# Altium schematic sheet ZX1541 Interfac in THT.SchDoc("ZX1541 Interfac in THT")
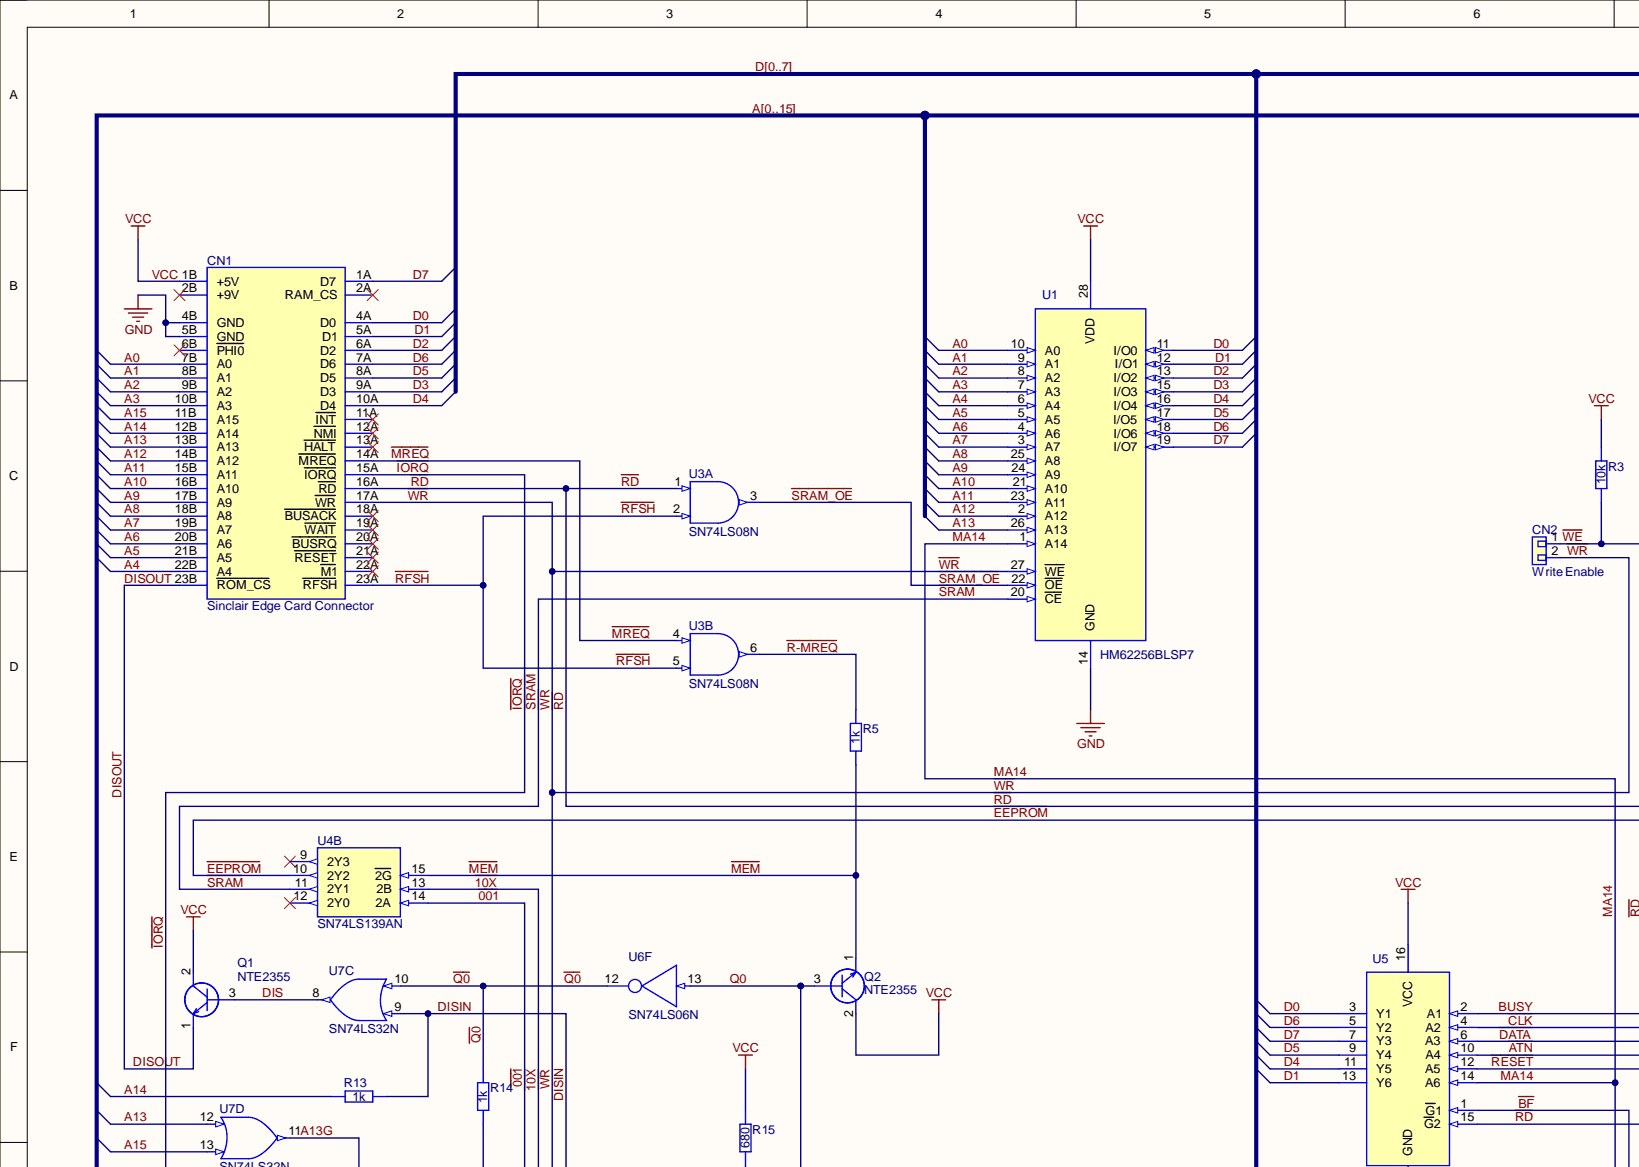
[diagram p0: ZX1541 Interfac in THT.SchDoc, top left]
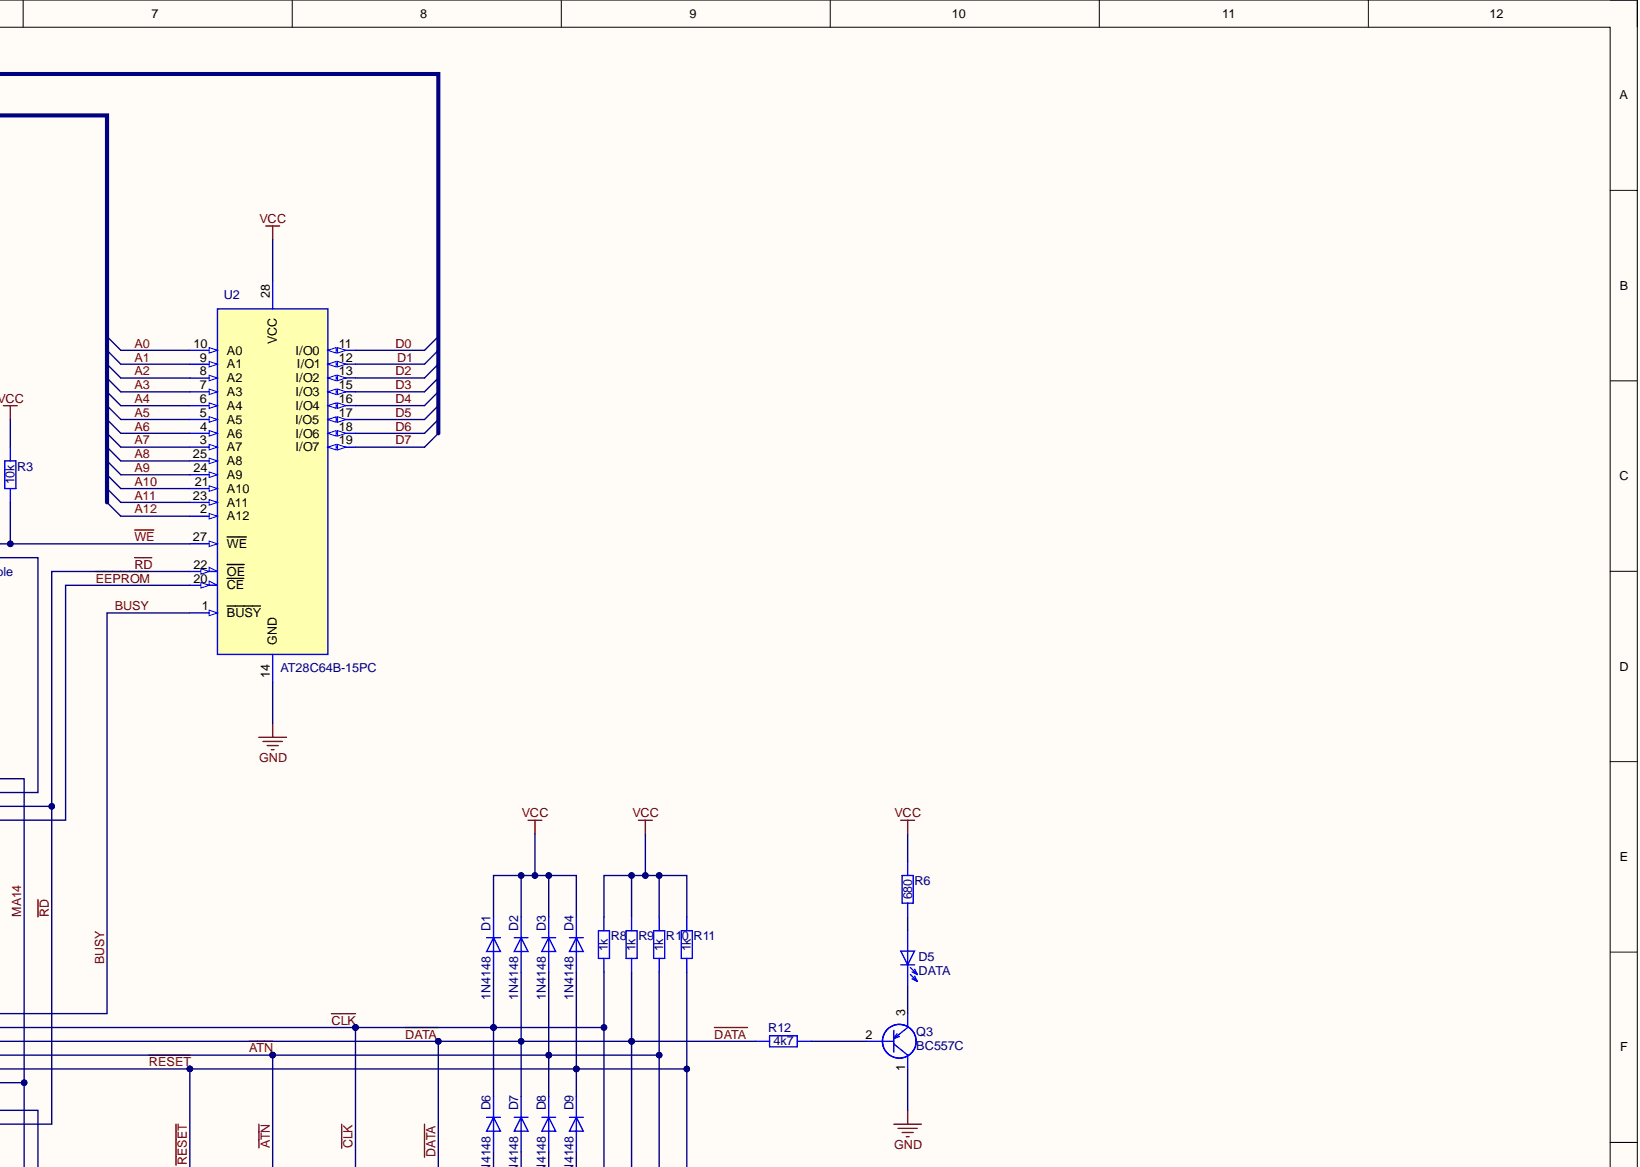
[diagram p1: ZX1541 Interfac in THT.SchDoc, top right]
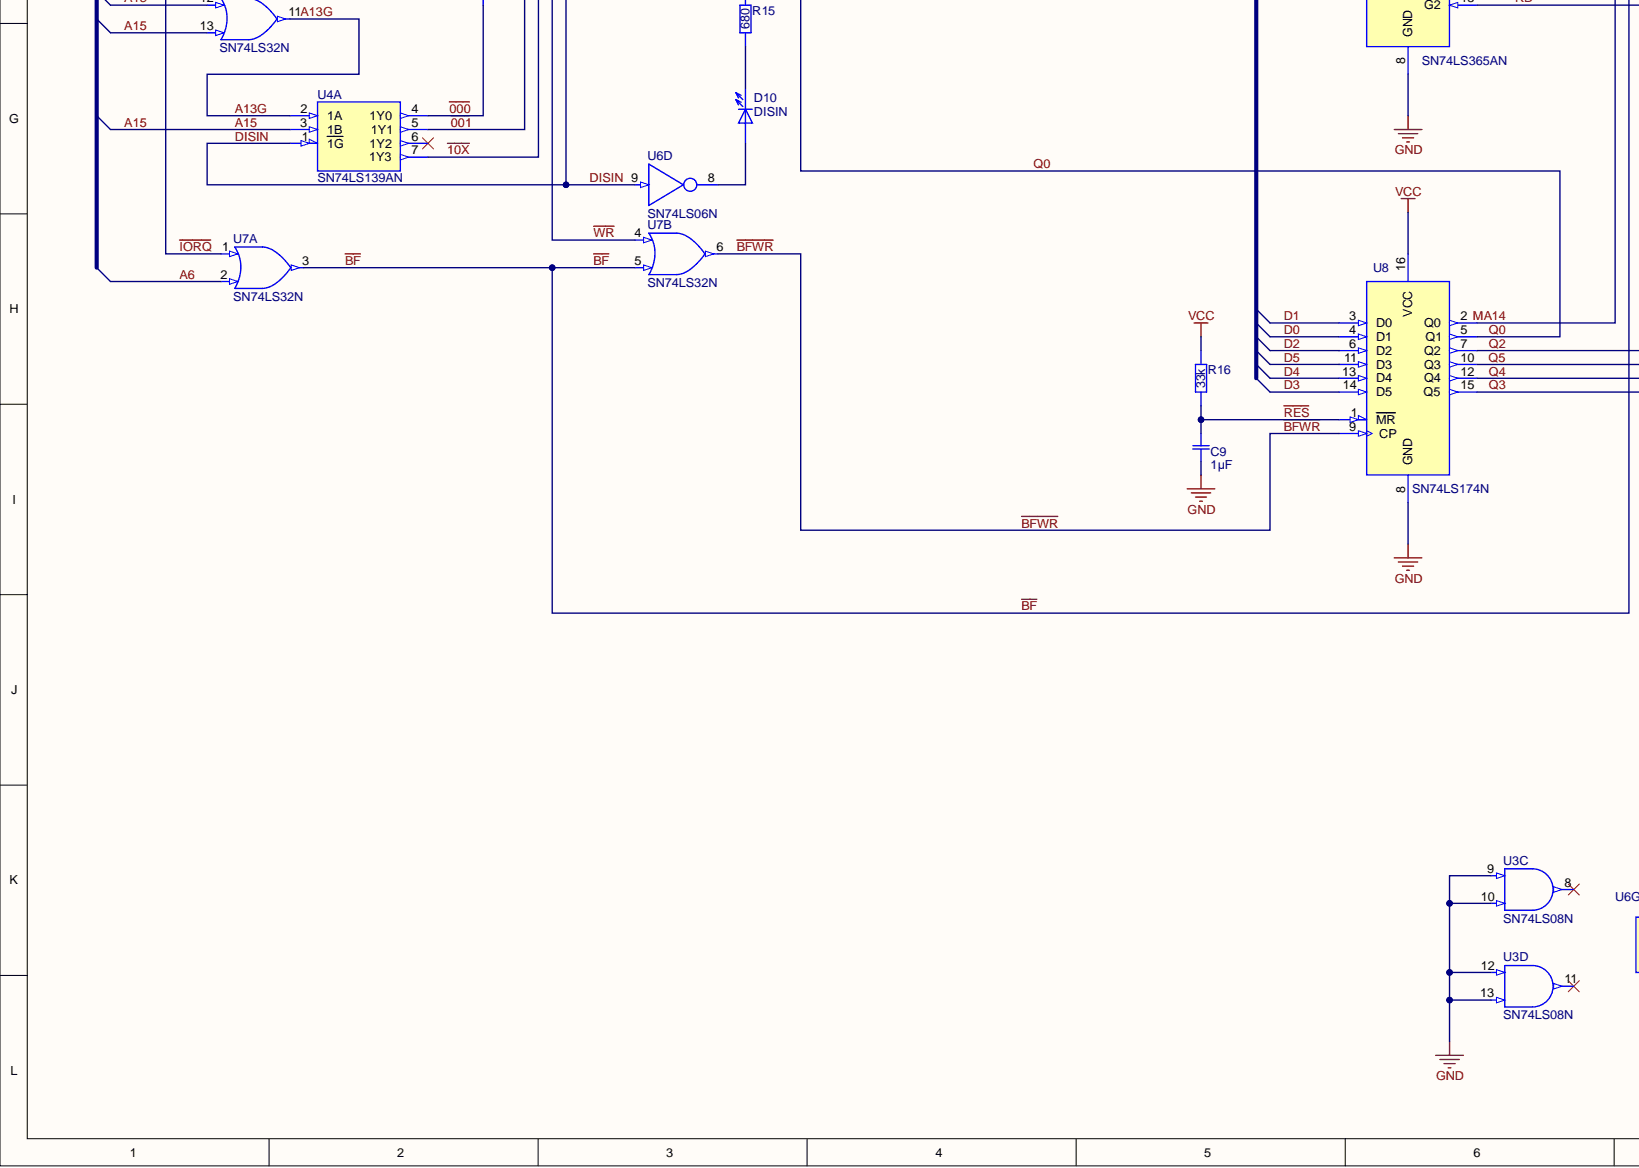
[diagram p2: ZX1541 Interfac in THT.SchDoc, bottom left]
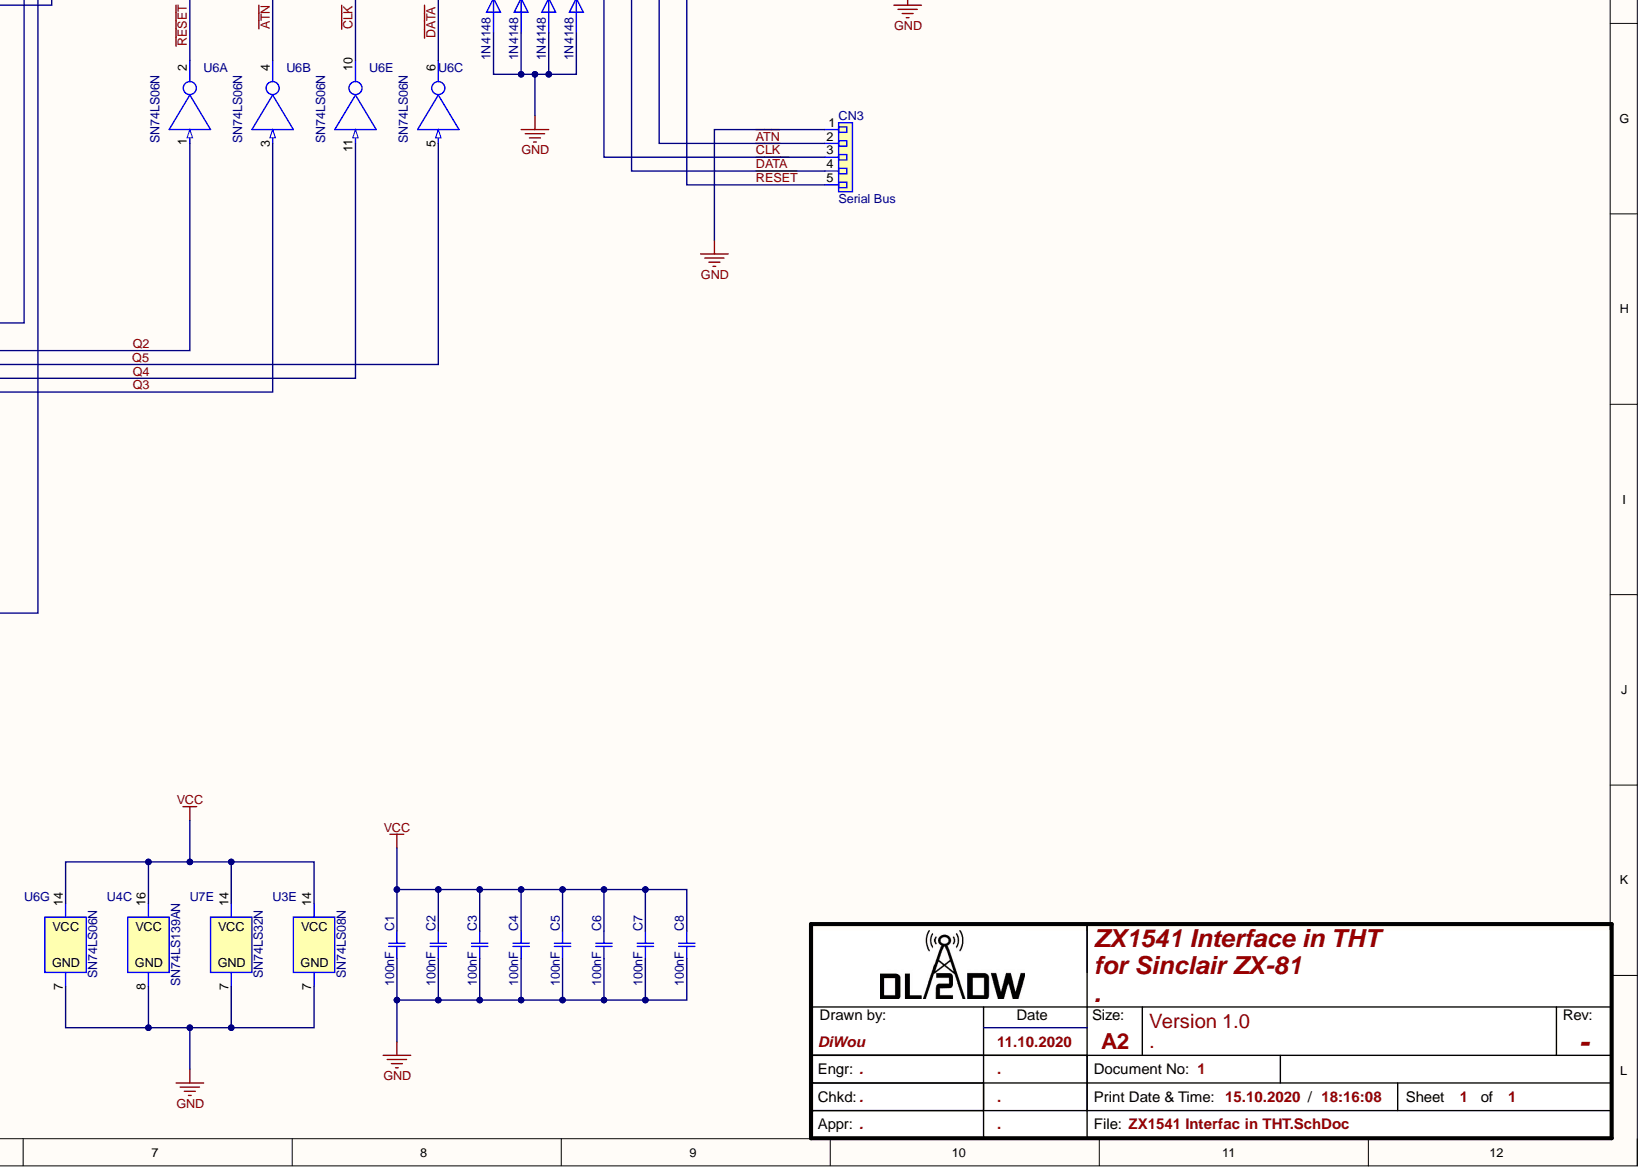
[diagram p3: ZX1541 Interfac in THT.SchDoc, bottom right]

## Parts
C1  100nF  [CAP Disc 100nF P2.54mm D5.5mm T3mm]
C2  100nF  [CAP Disc 100nF P2.54mm D5.5mm T3mm]
C3  100nF  [CAP Disc 100nF P2.54mm D5.5mm T3mm]
C4  100nF  [CAP Disc 100nF P2.54mm D5.5mm T3mm]
C5  100nF  [CAP Disc 100nF P2.54mm D5.5mm T3mm]
C6  100nF  [CAP Disc 100nF P2.54mm D5.5mm T3mm]
C7  100nF  [CAP Disc 100nF P2.54mm D5.5mm T3mm]
C8  100nF  [CAP Disc 100nF P2.54mm D5.5mm T3mm]
C9  1µF  [CAP 1µF C315C105K3R5TA P2.54mm]
CN1  Sinclair Edge Card Connector  [Sinclair Card Connector]
CN2  Write Enable  [Pin Header 01x02 2.54mm Horizontal Male]
CN3  Serial Bus  [01x05 RM2.0 mm Male Pin Header - MPE-Garry 150-1-005-0-S-XS0-0835]
D1  1N4148  [1N4148 P=5.08mm]
D2  1N4148  [1N4148 P=5.08mm]
D3  1N4148  [1N4148 P=5.08mm]
D4  1N4148  [1N4148 P=5.08mm]
D5  DATA  [LED 3mm Red Right Angle 4mm]
D6  1N4148  [1N4148 P=5.08mm]
D7  1N4148  [1N4148 P=5.08mm]
D8  1N4148  [1N4148 P=5.08mm]
D9  1N4148  [1N4148 P=5.08mm]
D10  DISIN  [LED 3mm Green Right Angle 4mm]
Q1  NTE2355  [NTE 2355]
Q2  NTE2355  [NTE 2355]
Q3  BC557C  [BC557C]
R3  10k  [10 kOhm R Axial DIN0207 L6.3mm D2.5mm P7.62mm Horizontal]
R5  1k  [1,0 kOhm R Axial DIN0207 L6.3mm D2.5mm P7.62mm Horizontal]
R6  680  [680 kOhm R Axial DIN0207 L6.3mm D2.5mm P7.62mm Horizontal]
R8  1k  [1,0 kOhm R Axial DIN0207 L6.3mm D2.5mm P7.62mm Horizontal]
R9  1k  [1,0 kOhm R Axial DIN0207 L6.3mm D2.5mm P7.62mm Horizontal]
R10  1k  [1,0 kOhm R Axial DIN0207 L6.3mm D2.5mm P7.62mm Horizontal]
R11  1k  [1,0 kOhm R Axial DIN0207 L6.3mm D2.5mm P7.62mm Horizontal]
R12  4k7  [4,7 kOhm R Axial DIN0207 L6.3mm D2.5mm P7.62mm Horizontal]
R13  1k  [1,0 kOhm R Axial DIN0207 L6.3mm D2.5mm P7.62mm Horizontal]
R14  1k  [1,0 kOhm R Axial DIN0207 L6.3mm D2.5mm P7.62mm Horizontal]
R15  680  [680 kOhm R Axial DIN0207 L6.3mm D2.5mm P7.62mm Horizontal]
R16  33k  [33 kOhm R Axial DIN0207 L6.3mm D2.5mm P7.62mm Horizontal]
U1  HM62256BLSP7  [Hitachi HM62256BLSP-7 SRAM 32kx8]
U2  AT28C64B-15PC  Memory Type: EEPROM  [28C64-150 with precision Socket]
U3  SN74LS08N  [SN74LS08N with Socket]  (parts A,B,C,D,E)
U4  SN74LS139AN  [SN74LS139N with Socket]  (parts A,B,C)
U5  SN74LS365AN  [SN74LS365A with Socket]
U6  SN74LS06N  [SN74LS06N with Socket]  (parts A,B,C,D,E,F,G)
U7  SN74LS32N  [SN74LS32N with Socket]  (parts A,B,C,D,E)
U8  SN74LS174N  [SN74LS174N with Socket]

## Nets
GND: C1.1 C2.1 C3.1 C4.1 C5.1 C6.1 C7.1 C8.1 C9.1 CN1.4B(GND) CN1.5B(GND) CN3.1 D6.2 D7.2 D8.2 D9.2 Q3.1 U1.14(GND) U2.14(GND) U3.7 U3.9 U3.10 U3.12 U3.13 U4.8 U5.8(GND) U6.7 U7.7 U8.8(GND)
~{000}: R14.1 U4.4(1Y0)
~{001}: U4.5(1Y1) U4.14(2A)
~{10X}: U4.7(1Y3) U4.13(2B)
A0: CN1.7B(A0) U1.10(A0) U2.10(A0)  [also labelled A]
A1: CN1.8B(A1) U1.9(A1) U2.9(A1)  [also labelled A]
A2: CN1.9B(A2) U1.8(A2) U2.8(A2)  [also labelled A]
A3: CN1.10B(A3) U1.7(A3) U2.7(A3)  [also labelled A]
A4: CN1.22B(A4) U1.6(A4) U2.6(A4)  [also labelled A]
A5: CN1.21B(A5) U1.5(A5) U2.5(A5)  [also labelled A]
A6: CN1.20B(A6) U1.4(A6) U2.4(A6) U7.2  [also labelled A]
A7: CN1.19B(A7) U1.3(A7) U2.3(A7)  [also labelled A]
A8: CN1.18B(A8) U1.25(A8) U2.25(A8)  [also labelled A]
A9: CN1.17B(A9) U1.24(A9) U2.24(A9)  [also labelled A]
A10: CN1.16B(A10) U1.21(A10) U2.21(A10)  [also labelled A]
A11: CN1.15B(A11) U1.23(A11) U2.23(A11)  [also labelled A]
A12: CN1.14B(A12) U1.2(A12) U2.2(A12)  [also labelled A]
A13: CN1.13B(A13) U1.26(A13) U7.12  [also labelled A]
A13G: U4.2(1A) U7.11
A14: CN1.12B(A14) R13.1  [also labelled A]
A15: CN1.11B(A15) U4.3(1B) U7.13  [also labelled A]
~{ATN}: CN3.2 D3.2 D8.1 R10.1 U5.10(A4) U6.4
~{BF}: U5.1(G1) U7.3 U7.5
~{BFWR}: U7.6 U8.9(CP)
BUSY: U2.1(BUSY) U5.2(A1)
~{CLK}: CN3.3 D1.2 D6.1 R8.1 U5.4(A2) U6.10
D0: CN1.4A(D0) U1.11(I/O0) U2.11(I/O0) U5.3(Y1) U8.4(D1)  [also labelled D]
D1: CN1.5A(D1) U1.12(I/O1) U2.12(I/O1) U5.13(Y6) U8.3(D0)  [also labelled D]
D2: CN1.6A(D2) U1.13(I/O2) U2.13(I/O2) U8.6(D2)  [also labelled D]
D3: CN1.9A(D3) U1.15(I/O3) U2.15(I/O3) U8.14(D5)  [also labelled D]
D4: CN1.10A(D4) U1.16(I/O4) U2.16(I/O4) U5.11(Y5) U8.13(D4)  [also labelled D]
D5: CN1.8A(D5) U1.17(I/O5) U2.17(I/O5) U5.9(Y4) U8.11(D3)  [also labelled D]
D6: CN1.7A(D6) U1.18(I/O6) U2.18(I/O6) U5.5(Y2)  [also labelled D]
D7: CN1.1A(D7) U1.19(I/O7) U2.19(I/O7) U5.7(Y3)  [also labelled D]
~{DATA}: CN3.4 D2.2 D7.1 R9.1 R12.1 U5.6(A3) U6.6
DIS: Q1.3 U7.8
DISIN: R13.2 U4.1(1G) U6.9 U7.9
DISOUT: CN1.23B(ROM_CS) Q1.1
~{EEPROM}: U2.20(CE) U4.10(2Y2)
~{IORQ}: CN1.15A(IORQ) U7.1
~{MEM}: Q2.1 R5.1 U4.15(2G)
~{MREQ}: CN1.14A(MREQ) U3.4
MA14: U1.1(A14) U5.14(A6) U8.2(Q0)
Q0: Q2.3 U6.13 U8.5(Q1)
Q2: U6.1 U8.7(Q2)
Q3: U6.3 U8.15(Q5)
Q4: U6.11 U8.12(Q4)
Q5: U6.5 U8.10(Q3)
~{Q0}: R14.2 U6.12 U7.10
~{R-MREQ}: R5.2 U3.6
~{RD}: CN1.16A(RD) U2.22(OE) U3.1 U5.15(G2)
~{RES}: C9.2 R16.1 U8.1(MR)
~{RESET}: CN3.5 D4.2 D9.1 R11.1 U5.12(A5) U6.2
~{RFSH}: CN1.23A(RFSH) U3.2 U3.5
~{SRAM}: U1.20(CE) U4.11(2Y1)
~{SRAM_OE}: U1.22(OE) U3.3
VCC: C1.2 C2.2 C3.2 C4.2 C5.2 C6.2 C7.2 C8.2 CN1.1B(+5V) D1.1 D2.1 D3.1 D4.1 Q1.2 Q2.2 R3.2 R6.2 R8.2 R9.2 R10.2 R11.2 R15.2 R16.2 U1.28(VDD) U2.28(VCC) U3.14 U4.16 U5.16(VCC) U6.14 U7.14 U8.16(VCC)
~{WE}: CN2.1 R3.1 U2.27(WE)
~{WR}: CN1.17A(WR) CN2.2 U1.27(WE) U7.4
NetD5_1: D5.1 Q3.3
NetD5_2: D5.2 R6.1
NetD10_1: D10.1 R15.1
NetD10_2: D10.2 U6.8
NetQ3_2: Q3.2 R12.2

Not connected: CN1 2A(RAM_CS) 2B(+9V) 6B(PHI0) 11A(INT) 12A(NMI) 13A(HALT) 18A(BUSACK) 19A(WAIT) 20A(BUSRQ) 21A(RESET) 22A(M1); U3 8 11; U4 6(1Y2) 9(2Y3) 12(2Y0)

## Library data of the parts
C1-C8 = CMP-Capacitors-Ceramic_Capacitors-00007-4: Ceramic Disc Capacitors 100nF -20%~+80% 50V Through Hole,P=2.54mm RoHS; Component Kind: Standard; ComponentLink1URL: https://lcsc.com/product-detail/Others_Dersonic-CC1H104ZA1PD3F5O3000_C353909.html; Library Name: PCB-Tech Cloud
C9 = CMP-Capacitors-Ceramic_Capacitors-00009-2: Multilayer Ceramic Capacitors MLCC - Leaded 25volts 1uF 10% X7R; Dissipation Factor: 3.5%; Lead Diameter: 0.51mm; Lead/Base Style: Straight; Temperature Coefficient: 2.2ppm/°C; Voltage: 25V; Capacitance: 1uF; Case/Package: Radial; Component Kind: Standard; Depth: 2.54mm; Dielectric: X7R; Dielectric Material: Ceramic; Height: 2.54mm; Height - Seated (Max): 0.21inch; Lead Pitch: 0.1inch; Length: 0.15inch; Library Name: PCB-Tech Cloud; Max Operating Temperature: 125°C; Min Operating Temperature: -55°C; Mount: Through Hole,PCB; Package Quantity: 500; Packaging: Bulk; Termination: Radial; Tolerance: 10%; Voltage Rating: 25V; Voltage Rating (DC): 25V; Width: 0.1inch
CN1 = CMP-Connectors-00027-6: Component Kind: Standard; Description: Sinclair Edge Card Connector; Library Name: PCB-Tech Cloud
CN2 = CMP-Connectors-Male_PIN_Headers-00001-4: CONN HEADER .100" SNGL STR 2POS; Connector Type: Male Pin; Number of Positions: 2; Pitch: 0.1inch; Color: Black; Component Kind: Standard; Contact Mating Length: 0.318inch; Contact Plating: Gold; Library Name: PCB-Tech Cloud; Mount: Through Hole; Number of Rows: 1; Package Quantity: 1; Packaging: Bulk; Termination: Solder
CN3 = CMP-Male_Pin_Headers-RM2.0_Male_Pin_Headers-00003-2: Conn Unshrouded Header HDR 5 POS 2mm Solder ST Thru-Hole Automotive Bulk; Gender: Male; Max Voltage Rating (AC): 500V; Number of Contacts: 5; Component Kind: Standard; Contact Material: Bronze; Contact Plating: Gold; Depth: 1.97mm; Housing Color: Black; Housing Material: Polymer; Lead Free: Lead Free; Length: 10mm; Library Name: PCB-Tech Cloud; Max Operating Temperature: 125°C; Min Operating Temperature: -55°C; Number of Rows: 1; Orientation: Straight; Packaging: Bulk; Pitch: 2mm; Radiation Hardening: No; Termination: Solder
D1-D4 D6-D9 = CMP-Diodes-00002-4: NEXPERIA - 1N4148 - DIODE, 1N4148 AMMO-BOX 10K; Capacitance: 4pF; Current: 4A; Current Rating: 200mA; Element Configuration: Single; Forward Voltage: 1V; Max Power Dissipation: 500mW; Max Repetitive Reverse Voltage (Vrrm): 100V; Polarity: Standard; Reverse Recovery Time: 4ns; Case/Package: SOD; Component Kind: Standard; Forward Current: 200mA; Height: 0.25inch; Lead Free: Lead Free; Length: 2.5inch; Library Name: PCB-Tech Cloud; Max Forward Surge Current (Ifsm): 4A; Max Operating Temperature: 200°C; Min Operating Temperature: -65°C; Number of Pins: 2; Output Current: 200mA; Packaging: Ammo Pack; Peak Non-Repetitive Surge Current: 4A; Radiation Hardening: No; REACH SVHC: No SVHC; Termination: Axial; Voltage: 100V; Voltage Rating (DC): 100V; Weight: 0.01lb; Width: 0.25inch
D5 = CMP-LEDs-00000-4: WURTH ELEKTRONIK   151033RS03000   LED, 3MM,  RED, 2600MCD, 621NM; Forward Current: 30mA; Forward Voltage: 2V; Lens Style: Clear,Circular,Round; Test Current: 20mA; Viewing Angle: 30°; Case/Package: Radial; Color: Red; Component Kind: Standard; Dominant Wavelength: 621nm; Height: 5.55mm; Lens Size: 3mm; Library Name: PCB-Tech Cloud; Luminous Intensity: 2600mcd; Max Operating Temperature: 85°C; Min Operating Temperature: -40°C; Mount: Through Hole; Package Quantity: 1; Packaging: Bulk; REACH SVHC: Unknown; Wavelength: 621nm
D10 = CMP-LEDs-00007-1: WURTH ELEKTRONIK - 151033GS03000 - LED, Green, T-1 (3mm), 530 nm, 3.2 V, 30 mA, 15 cd RoHS Compliant: Yes; Dominant Wavelength: 530nm; Forward Current: 30mA; Forward Voltage: 3.2V; Lens Style: Clear,Round,Circular; Luminous Intensity: 15000mcd; Test Current: 20mA; Viewing Angle: 30°; Case/Package: Radial; Color: Green; Component Kind: Standard; Height: 5.55mm; Lens Size: 3mm; Library Name: PCB-Tech Cloud; Max Operating Temperature: 85°C; Min Operating Temperature: -40°C; Mount: Through Hole; Package Quantity: 1; Packaging: Bulk; REACH SVHC: Unknown; Wavelength: 530nm
Q1 Q2 = CMP-Transistors-00009-1: PRE-BIASED DIG TRANSISTOR, 50V, TO-92; Transistor Polarity:NPN; Collector Emitter Voltage V(br)ceo:50V; Transition Frequency ft:250MHz; Power Dissipation Pd:300mW; DC Collector Current:100mA; DC Current Gain hFE:50; No. of Pins:3 ;RoHS Compliant: Yes; Element Configuration: Single; Max Collector Current: 200mA; Max Power Dissipation: 300mW; Polarity: NPN; Transition Frequency: 250MHz; Case/Package: TO-92; Collector Base Voltage (VCBO): 50V; Collector Emitter Breakdown Voltage: 50V; Collector Emitter Voltage (VCEO): 50V; Component Kind: Standard; Height: 0.5inch; Length: 6inch; Library Name: PCB-Tech Cloud; Max Operating Temperature: 150°C; Min Operating Temperature: -55°C; Mount: Through Hole; Number of Pins: 3; Power Dissipation: 300mW; REACH SVHC: No SVHC; Weight: 0.016lb; Width: 3inch
Q3 = CMP-Transistors-00003-1: Trans GP BJT PNP 45V 0.1A Automotive 3-Pin TO-92 T/R; Collector Base Voltage (VCBO): 50V; Emitter Base Voltage (VEBO): 5V; Frequency: 150MHz; Number of Elements: 1; Power Dissipation: 500mW; Case/Package: TO-92; Collector Emitter Voltage (VCEO): 45V; Component Kind: Standard; Library Name: PCB-Tech Cloud; Max Operating Temperature: 150°C; Min Operating Temperature: -55°C; Mount: Through Hole; Number of Pins: 3; Packaging: Tape and Reel; Polarity: PNP
R3 = CMP-Resistors-Yageo_THT_DIN_0207-00096-1: RES 10K OHM 1/4W 5% AXIAL; Max Power Dissipation: 250mW; Resistance: 10kR; Temperature Coefficient: 500ppm/°C; Case/Package: Axial; Component Kind: Standard; Composition: Carbon Film; Lead Free: Lead Free; Length: 0.248inch; Library Name: PCB-Tech Cloud; Max Operating Temperature: 155°C; Min Operating Temperature: -55°C; Number of Terminations: 2; Package Quantity: 200; Packaging: Bulk; Tolerance: 5%
R5 R8-R11 R13 R14 = CMP-Resistors-Yageo_THT_DIN_0207-00072-1: RES 1K OHM 1/4W 5% AXIAL; Max Power Dissipation: 250mW; Resistance: 1kR; Temperature Coefficient: 500ppm/°C; Case/Package: Axial; Component Kind: Standard; Composition: Carbon Film; Lead Free: Lead Free; Length: 0.248inch; Library Name: PCB-Tech Cloud; Max Operating Temperature: 155°C; Min Operating Temperature: -55°C; Number of Terminations: 2; Package Quantity: 200; Packaging: Bulk; Power Rating: 250mW; Tolerance: 5%
R6 R15 = CMP-Resistors-Yageo_THT_DIN_0207-00140-1: Res 680K Ohm 1/4W 5% Axial; Max Power Dissipation: 250mW; Resistance: 680kR; Temperature Coefficient: -700ppm/°C; Case/Package: Axial; Component Kind: Standard; Composition: Carbon Film; Length: 0.248inch; Library Name: PCB-Tech Cloud; Max Operating Temperature: 155°C; Min Operating Temperature: -55°C; Number of Terminations: 2; Package Quantity: 200; Packaging: Bulk; Tolerance: 5%
R12 = CMP-Resistors-Yageo_THT_DIN_0207-00088-1: RES 4.7K OHM 1/4W 5% AXIAL; Max Power Dissipation: 250mW; Resistance: 4.7kR; Temperature Coefficient: 500ppm/°C; Case/Package: Axial; Component Kind: Standard; Composition: Carbon Film; Lead Free: Lead Free; Length: 0.248inch; Library Name: PCB-Tech Cloud; Max Operating Temperature: 155°C; Min Operating Temperature: -55°C; Number of Terminations: 2; Package Quantity: 200; Packaging: Bulk; Power Rating: 250mW; Tolerance: 5%
R16 = CMP-Resistors-Yageo_THT_DIN_0207-00108-1: RES 33K OHM 1/4W 5% AXIAL; Max Power Dissipation: 250mW; Resistance: 33kR; Temperature Coefficient: 500ppm/°C; Case/Package: Axial; Component Kind: Standard; Composition: Carbon Film; Length: 0.248inch; Library Name: PCB-Tech Cloud; Max Operating Temperature: 155°C; Min Operating Temperature: -55°C; Number of Terminations: 2; Package Quantity: 200; Packaging: Bulk; Tolerance: 5%
U1 = CMP-ICs-Memory-00014-1: Contact for details; Case/Package: PDIP; Component Kind: Standard; Library Name: PCB-Tech Cloud
U2 = CMP-ICs-Memory-00010-3: IC EEPROM 64KBIT 150NS 28DIP; Density: 64Kb; Frequency: 150GHz; Interface: SPI,Parallel; Max Supply Voltage: 5.5V; Min Supply Voltage: 4.5V; Nominal Supply Current: 40mA; Access Time: 150ns; Case/Package: PDIP; Component Kind: Standard; Lead Free: Contains Lead; Library Name: PCB-Tech Cloud; Max Operating Temperature: 70°C; Memory Size: 64Kb; Min Operating Temperature: 0°C; Mount: Through Hole; Number of Pins: 28; Package Quantity: 14; Packaging: Rail/Tube
U3 = CMP-ICs-74xx-series-00004-2: IC GATE AND 4CH 2-INP 14DIP; High Level Output Current: -400uA; Low Level Output Current: 8mA; Max Supply Voltage: 5.25V; Min Supply Voltage: 4.75V; Number of Bits: 4; Number of Input Lines: 2; Number of Output Lines: 1; Operating Supply Voltage: 5V; Propagation Delay: 20ns; Quiescent Current: 4.4mA; Schmitt Trigger Input: No; Turn-On Delay Time: 25ns; Case/Package: PDIP; Component Kind: Standard; Contact Plating: Gold; Height: 4.57mm; Lead Free: Lead Free; Length: 19.3mm; Library Name: PCB-Tech Cloud; Logic Function: AND; Max Operating Temperature: 70°C; Max Output Current: 8mA; Min Operating Temperature: 0°C; Mount: Through Hole; Number of Circuits: 4; Number of Elements: 4; Number of Gates: 4; Number of Inputs: 2; Number of Outputs: 1; Number of Pins: 14; Output Current: 8mA; Package Quantity: 25; Packaging: Rail/Tube; Radiation Hardening: No; REACH SVHC: No SVHC; Termination: Through Hole; Weight: 0.032734oz; Width: 6.35mm
U4 = CMP-ICs-74xx-series-00043-5: TEXAS INSTRUMENTS         SN74LS139AN            IC, DECODER/DEMUX, 74LS139; Bandwidth: 35MHz; High Level Output Current: -400uA; Independent Circuits: 2; Logic Function: Demultiplexer,Decoder; Low Level Output Current: 8mA; Max Power Dissipation: 200mW; Max Supply Voltage: 5.25V; Min Supply Voltage: 4.75V; Nominal Supply Current: 100uA; Number of Bits: 4; Operating Supply Voltage: 5V; Polarity: Inverting; Propagation Delay: 33ns; Supply Type: Single; Throw Configuration: DP4T; Turn-On Delay Time: 38ns; Case/Package: PDIP; Component Kind: Standard; Height: 4.57mm; Lead Free: Lead Free; Length: 19.3mm; Library Name: PCB-Tech Cloud; Max Operating Temperature: 70°C; Min Operating Temperature: 0°C; Mount: Through Hole; Number of Channels: 2; Number of Circuits: 2; Number of Elements: 2; Number of Input Lines: 2; Number of Inputs: 2; Number of Output Lines: 4; Number of Outputs: 4; Number of Pins: 16; Package Quantity: 25; Packaging: Rail/Tube; Radiation Hardening: No; REACH SVHC: No SVHC; Weight: 0.03357oz; Width: 6.35mm
U5 = CMP-ICs-74xx-series-00064-1: Buffer/Line Driver 6-CH Non-Inverting 3-ST Bipolar 16-Pin PDIP Tube; High Level Output Current: -2.6mA; Logic Function: Buffer,Inverting; Low Level Output Current: 24mA; Max Supply Voltage: 5.25V; Min Supply Voltage: 4.75V; Number of Bits: 6; Number of Elements: 1; Operating Supply Voltage: 5V; Propagation Delay: 22ns; Schmitt Trigger Input: No; Case/Package: PDIP; Component Kind: Standard; Height: 4.57mm; Lead Free: Lead Free; Length: 19.3mm; Library Name: PCB-Tech Cloud; Max Operating Temperature: 70°C; Max Output Current: 24mA; Min Operating Temperature: 0°C; Mount: Through Hole; Number of Bits per Element: 6; Number of Channels: 6; Number of Input Lines: 6; Number of Inputs: 6; Number of Output Lines: 6; Number of Outputs: 6; Number of Pins: 16; Output Current: 24mA; Package Quantity: 25; Packaging: Rail/Tube; Polarity: Non-Inverting; REACH SVHC: No SVHC; Termination: Through Hole; Turn-On Delay Time: 22ns; Width: 6.35mm
U6 = CMP-ICs-74xx-series-00008-2: IC INVERTER OPEN COL 6CH 14DIP; High Level Output Current: -250uA; Logic Function: Buffer,Inverter,Inverting; Low Level Output Current: 40mA; Max Supply Voltage: 5.25V; Min Supply Voltage: 4.75V; Nominal Supply Current: 100uA; Number of Bits: 6; Number of Inputs: 1; Operating Supply Voltage: 5V; Polarity: Inverting; Propagation Delay: 20ns; Schmitt Trigger Input: No; Case/Package: PDIP; Component Kind: Standard; Height: 4.57mm; Lead Free: Lead Free; Length: 19.3mm; Library Name: PCB-Tech Cloud; Max Operating Temperature: 70°C; Min Operating Temperature: 0°C; Mount: Through Hole; Number of Channels: 6; Number of Circuits: 6; Number of Elements: 6; Number of Gates: 6; Number of Input Lines: 6; Number of Output Lines: 6; Number of Outputs: 6; Number of Pins: 14; Package Quantity: 25; Packaging: Rail/Tube; Radiation Hardening: No; REACH SVHC: No SVHC; Termination: Through Hole; Turn-On Delay Time: 20ns; Width: 6.35mm
U7 = CMP-ICs-74xx-series-00063-1: Texas Instruments SN74LS32N, Quad 2-Input OR Logic Gate, 4.75  5.25 V, 14-Pin PDIP; High Level Output Current: -400uA; Logic Function: OR GATE; Low Level Output Current: 8mA; Max Supply Voltage: 5.25V; Min Supply Voltage: 4.75V; Nominal Supply Current: 100uA; Number of Bits: 4; Number of Input Lines: 2; Number of Output Lines: 1; Operating Supply Voltage: 5V; Output Type: TTL; Propagation Delay: 22ns; Quiescent Current: 4.9mA; Schmitt Trigger Input: No; Case/Package: PDIP; Component Kind: Standard; Contact Plating: Gold; Height: 4.57mm; Lead Free: Lead Free; Length: 19.3mm; Library Name: PCB-Tech Cloud; Max Operating Temperature: 70°C; Min Operating Temperature: 0°C; Mount: Through Hole; Number of Circuits: 4; Number of Elements: 4; Number of Gates: 4; Number of Inputs: 2; Number of Outputs: 1; Number of Pins: 14; Output Current: 8mA; Package Quantity: 25; Packaging: Rail/Tube; Radiation Hardening: No; REACH SVHC: No SVHC; Termination: Through Hole; Turn-On Delay Time: 22ns; Weight: 0.032734oz; Width: 6.35mm
U8 = CMP-ICs-74xx-series-00057-1: Flip Flop D-Type Bus Interface Pos-Edge 1-Element 16-Pin PDIP Tube; Clock Edge Trigger Type: Positive Edge; Frequency: 40MHz; High Level Output Current: -400uA; Logic Function: D-Type,Flip-Flop; Low Level Output Current: 8mA; Max Supply Voltage: 5.25V; Min Supply Voltage: 4.75V; Number of Bits: 6; Number of Circuits: 7; Number of Elements: 1; Number of Input Lines: 2; Operating Supply Voltage: 5V; Output Type: TTL; Polarity: Non-Inverting; Propagation Delay: 30ns; Turn-On Delay Time: 20ns; Case/Package: PDIP; Component Kind: Standard; Height: 4.57mm; Lead Free: Lead Free; Length: 19.3mm; Library Name: PCB-Tech Cloud; Max Frequency: 40MHz; Max Operating Temperature: 70°C; Min Operating Temperature: 0°C; Mount: Through Hole; Number of Bits per Element: 6; Number of Output Lines: 2; Number of Pins: 16; Output Current: 8mA; Package Quantity: 25; Packaging: Rail/Tube; Radiation Hardening: No; REACH SVHC: No SVHC; Weight: 0.03357oz; Width: 6.35mm
